# Altium schematic sheet Schematic_Sheet2.SchDoc(Schematic_Sheet2)
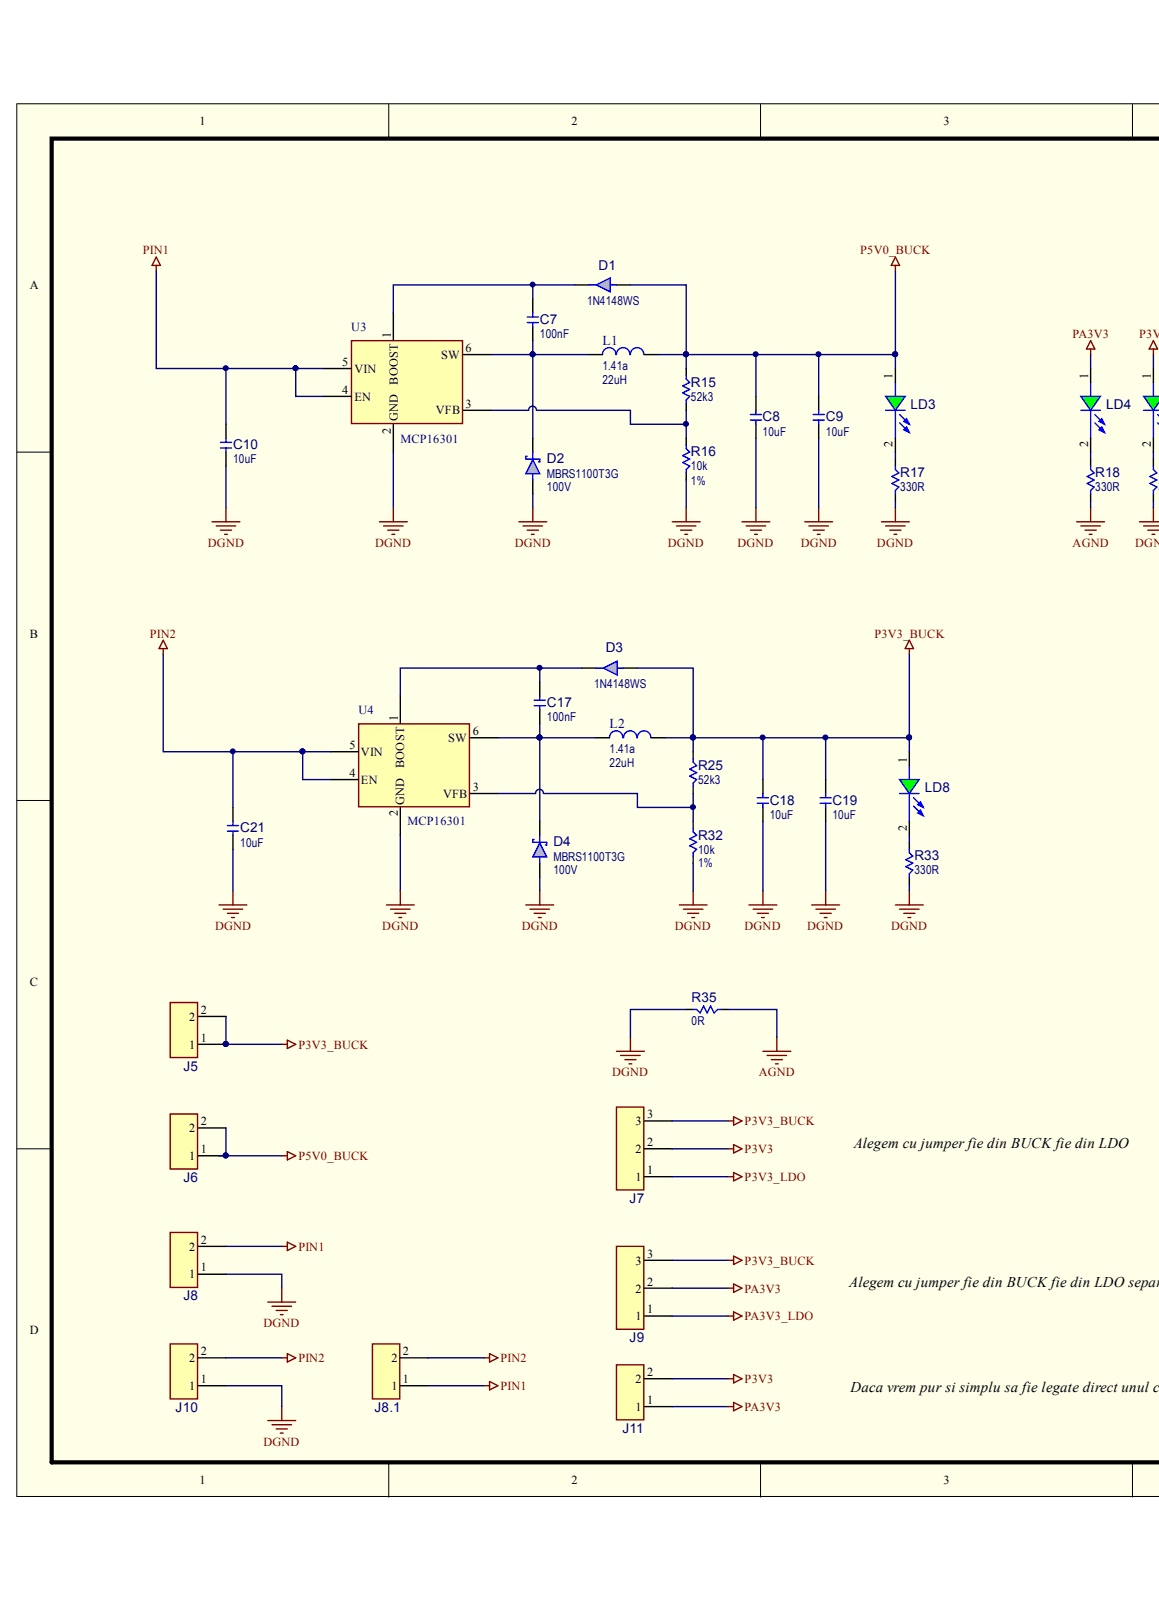
[diagram p0: Schematic_Sheet2.SchDoc, left]
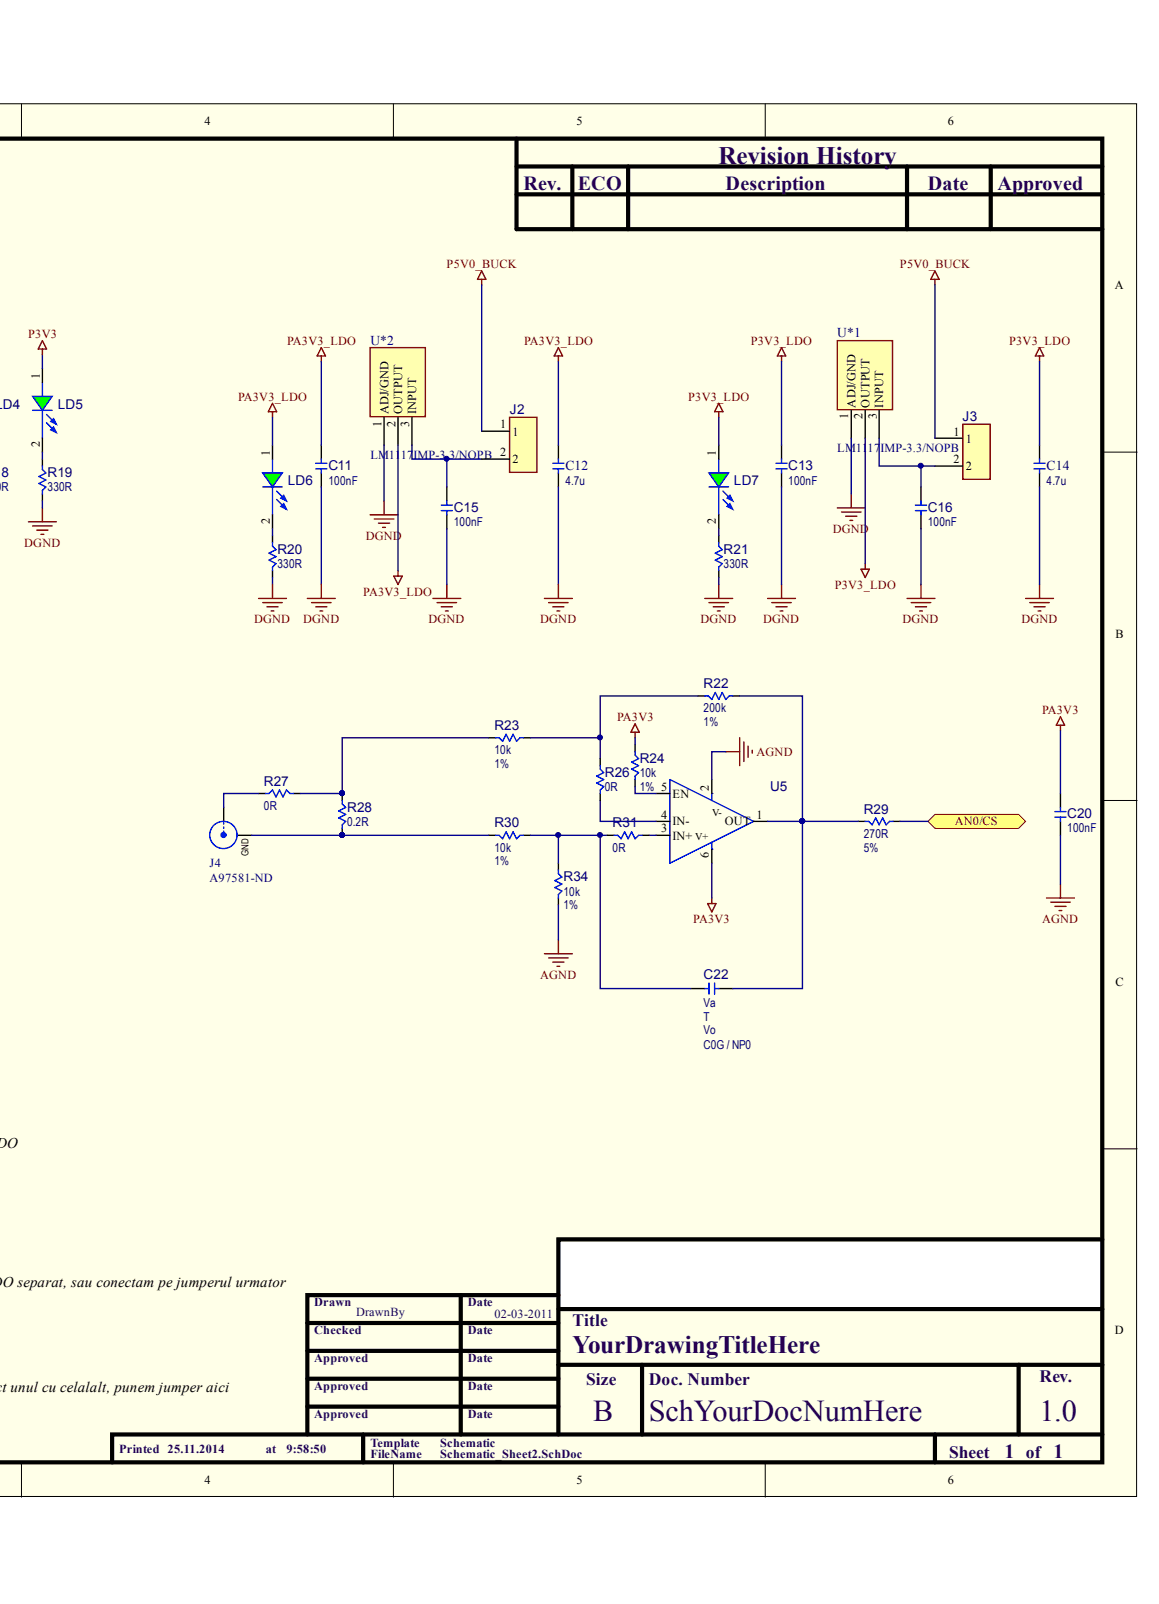
[diagram p1: Schematic_Sheet2.SchDoc, right]

## Parts
C7  value 100nF  (hidden comment: 06035C104JAT2A)
C8  value 10uF  (hidden comment: C3225X7S1H106M250AB)
C9  value 10uF  (hidden comment: C3225X7S1H106M250AB)
C10  value 10uF  (hidden comment: C3225X7S1H106M250AB)
C11  value 100nF  (hidden comment: 06035C104JAT2A)
C12  value 4.7u  (hidden comment: GRM21BR61C475KA88L)
C13  value 100nF  (hidden comment: 06035C104JAT2A)
C14  value 4.7u  (hidden comment: GRM21BR61C475KA88L)
C15  value 100nF  (hidden comment: 06035C104JAT2A)
C16  value 100nF  (hidden comment: 06035C104JAT2A)
C17  value 100nF  (hidden comment: 06035C104JAT2A)
C18  value 10uF  (hidden comment: C3225X7S1H106M250AB)
C19  value 10uF  (hidden comment: C3225X7S1H106M250AB)
C20  value 100nF  (hidden comment: 0603YC104JAT2A)
C21  value 10uF  (hidden comment: C3225X7S1H106M250AB)
C22  value Va  Tolerance: T  Voltage: Vo  (hidden comment: CAP_NP_0603)
D1  1N4148WS
D2  MBRS1100T3G  Vrrm: 100V
D3  1N4148WS
D4  MBRS1100T3G  Vrrm: 100V
J2  CON2  (value not drawn)
J3  CON2  (value not drawn)
J4  value A97581-ND  (hidden comment: BNC_Vert_5-1634503-1)
J5  CON2  (value not drawn)
J6  CON2  (value not drawn)
J7  CON3  (value not drawn)
J8  CON2  (value not drawn)
J8.1  CON2  (value not drawn)
J9  CON3  (value not drawn)
J10  CON2  (value not drawn)
J11  CON2  (value not drawn)
L1  value 22uH  Current: 1.41a  (hidden comment: IND_SMD_22uH_1.41A)
L2  value 22uH  Current: 1.41a  (hidden comment: IND_SMD_22uH_1.41A)
LD3  LED_GREEN_0603  (value not drawn)
LD4  LED_GREEN_0603  (value not drawn)
LD5  LED_GREEN_0603  (value not drawn)
LD6  LED_GREEN_0603  (value not drawn)
LD7  LED_GREEN_0603  (value not drawn)
LD8  LED_GREEN_0603  (value not drawn)
R15  value 52k3  (hidden comment: ERJ3EKF5232V)
R16  value 10k  Tolerance: 1%  (hidden comment: RES_0603_10k_1p)
R17  value 330R  (hidden comment: MCMR06X3300FTL)
R18  value 330R  (hidden comment: MCMR06X3300FTL)
R19  value 330R  (hidden comment: MCMR06X3300FTL)
R20  value 330R  (hidden comment: MCMR06X3300FTL)
R21  value 330R  (hidden comment: MCMR06X3300FTL)
R22  value 200k  Tolerance: 1%  (hidden comment: MCMR06X2003FTL)
R23  value 10k  Tolerance: 1%  (hidden comment: MCMR06X1002FTL)
R24  value 10k  Tolerance: 1%  (hidden comment: MCMR06X1002FTL)
R25  value 52k3  (hidden comment: ERJ3EKF5232V)
R26  value 0R  (hidden comment: ERJ3GEY0R00V)
R27  value 0R  (hidden comment: ERJ3GEY0R00V)
R28  value 0.2R  [Chip Resistor, 2-Leads, Body 3.2x1.6mm, IPC Medium Density]  (hidden comment: RES_0603)
R29  value 270R  Tolerance: 5%  (hidden comment: ERJ3GEYJ271V)
R30  value 10k  Tolerance: 1%  (hidden comment: MCMR06X1002FTL)
R31  value 0R  (hidden comment: ERJ3GEY0R00V)
R32  value 10k  Tolerance: 1%  (hidden comment: RES_0603_10k_1p)
R33  value 330R  (hidden comment: MCMR06X3300FTL)
R34  value 10k  Tolerance: 1%  (hidden comment: MCMR06X1002FTL)
R35  value 0R  (hidden comment: ERJ3GEY0R00V)
U3  MCP16301
U4  MCP16301
U5  LMP7711MK  (value not drawn)
U*1  LM1117IMP-3.3/NOPB
U*2  LM1117IMP-3.3/NOPB

## Ports
AN0/CS

## Nets
AGND: C20.1 R18.1 R34.1 R35.2 U5.2(V-)
DGND: C8.1 C9.1 C10.1 C11.1 C12.1 C13.1 C14.1 C15.1 C16.1 C18.1 C19.1 C21.1 D2.1 D4.1 J8.1 J10.1 R16.1 R17.1 R19.1 R20.1 R21.1 R32.1 R33.1 R35.1 U3.2(GND) U4.2(GND) U*1.1 U*2.1
P3V3: J7.2 J11.2 LD5.1
P3V3_BUCK: C18.2 C19.2 D3.1 J5.1 J5.2 J7.3 J9.3 L2.2 LD8.1 R25.2
P3V3_LDO: C13.2 C14.2 J7.1 LD7.1 U*1.2
P5V0_BUCK: C8.2 C9.2 D1.1 J2.1 J3.1 J6.1 J6.2 L1.2 LD3.1 R15.2
PA3V3: C20.2 J9.2 J11.1 LD4.1 R24.2 U5.6
PA3V3_LDO: C11.2 C12.2 J9.1 LD6.1 U*2.2
PIN1: C10.2 J8.2 J8.1.1 U3.4(EN) U3.5(VIN)
PIN2: C21.2 J8.1.2 J10.2 U4.4(EN) U4.5(VIN)
AN0/CS: R29.2 ; port AN0/CS
NetC7_1: C7.1 D2.2 L1.1 U3.6(SW)
NetC7_2: C7.2 D1.2 U3.1(BOOST)
NetC15_2: C15.2 J2.2 U*2.3
NetC16_2: C16.2 J3.2 U*1.3
NetC17_1: C17.1 D4.2 L2.1 U4.6(SW)
NetC17_2: C17.2 D3.2 U4.1(BOOST)
NetC22_1: C22.1 R30.2 R31.1 R34.2
NetC22_2: C22.2 R22.2 R29.1 U5.1(OUT)
NetJ4_1: J4.1 R27.1
NetJ4_2: J4.2 R28.2 R30.1
NetLD3_2: LD3.2 R17.2
NetLD4_2: LD4.2 R18.2
NetLD5_2: LD5.2 R19.2
NetLD6_2: LD6.2 R20.2
NetLD7_2: LD7.2 R21.2
NetLD8_2: LD8.2 R33.2
NetR15_1: R15.1 R16.2 U3.3(VFB)
NetR22_1: R22.1 R23.2 R26.2
NetR23_1: R23.1 R27.2 R28.1
NetR24_1: R24.1 U5.5(EN)
NetR25_1: R25.1 R32.2 U4.3(VFB)
NetR26_1: R26.1 U5.4(IN-)
NetR31_2: R31.2 U5.3(IN+)

## Library data of the parts
C7 C11 C13 C15-C17 = (no symbol name): Manufacturer: AVX; Type: SMD_0402; Type_Cap: Ceramic; Tolerance: 5%; Voltage: 50V; Dielectric: X7R; Supplier: Farnell; Supplier Part Number: 1740621; Manufacturer Part Number: 06035C104JAT2A
C8-C10 C18 C19 C21 = (no symbol name): Manufacturer: TDK; Type: SMD_1206; Type_Cap: Ceramic; Tolerance: 20%; Voltage: 50V; Dielectric: X7S; Supplier: Farnell; Supplier Part Number: 2309045; Manufacturer Part Number: C3225X7S1H106M250AB
C12 C14 = (no symbol name): Manufacturer: MURATA; Dielectric: X5R; Tolerance: 10%; Type: SMD_0805; Voltage: 16V; Type_CAP: Ceramic; Manufacturer Part Number: GRM21BR61C475KA88L; Supplier: Farnell; Supplier Part Number: 1907509
C20 = (no symbol name): Manufacturer: AVX; Type: SMD_0603; Type_Cap: Ceramic; Tolerance: 5%; Voltage: 16V; Dielectric: X7R; Supplier: Farnell; Supplier Part Number: 1740612; Manufacturer Part Number: 0603YC104JAT2A
C22 = (no symbol name): Type: SMD_0603; Type_Cap: Ceramic; Dielectric: C0G / NP0; Manufacturer: *; Supplier: Farnell; Supplier Part Number: *; Manufacturer Part Number: *; ESR: *
D1 D3 = (no symbol name): Manufacturer: MULTICOMP; If: 150mA; Vrmm: 100V; Manufacturer Part Number: 1N4148WS; Supplier: Farnell; Supplier Part Number: 1466524; Value: 1N4148WS
D2 D4 = (no symbol name): Manufacturer: ON SEMICONDUCTOR; If: 1A; Manufacturer Part Number: MBRS1100T3G; Supplier: Farnell; Supplier Part Number: 9555862; Value: MBRS1100T3G
J4 = (no symbol name): Manufacturer: TE Connectivity; Supplier: Farnell; Manufacturer Part Number: 5-1634503-1; Supplier Part Number: 1020980
L1 L2 = (no symbol name): Manufacturer: WURTH; Manufacturer Part Number: 7447779122; Tolerance: 20%; Supplier: Farnell; Supplier Part Number: 1635991
LD3-LD8 = (no symbol name): Manufacturer: OSRAM; Manufacturer Part Number: LGQ971; Type: SMD_0603; Vf: 2.2V; If: 20mA; Color: Green; Supplier: Farnell; Supplier Part Number: 1226372
R15 R25 = (no symbol name): Manufacturer: PANASONIC; Power: 100mW; Tolerance: 1%; Manufacturer Part Number: ERJ3EKF5232V; Type: SMD_0603; Supplier: Farnell; Supplier Part Number: 2059474
R16 R32 = (no symbol name): Manufacturer: MULTICOMP; Manufacturer Part Number: MCMR06X1002FTL; Power: 100mW; Type: SMD_0603; Supplier: Farnell; Supplier Part Number: 2073349
R17-R21 R33 = (no symbol name): Manufacturer: MULTICOMP; Power: 125mW; Tolerance: 1%; Manufacturer Part Number: MCMR06X3300FTL; Type: SMD_0603; Supplier: Farnell; Supplier Part Number: 2073472
R22-R24 R30 R34 = (no symbol name): Manufacturer: MULTICOMP; Power: 100mW; Type: SMD_0603; Supplier: Farnell
  R22: Manufacturer Part Number: MCMR06X2003FTL; Supplier Part Number: 2073422
  R23 R24 R30 R34: Manufacturer Part Number: MCMR06X1002FTL; Supplier Part Number: 2073349
R26 R27 R29 R31 R35 = (no symbol name): Manufacturer: PANASONIC; Power: 100mW; Type: SMD_0603; Supplier: Farnell
  R26 R27 R31 R35: Manufacturer Part Number: ERJ3GEY0R00V; Supplier Part Number: 2059527; Tolerance: -
  R29: Manufacturer Part Number: ERJ3GEYJ271V; Supplier Part Number: 2059585
U3 U4 = (no symbol name): Manufacturer: Microchip; Manufacturer Part Number: MCP16301T-E/CH; Supplier Part Number: MCP16301T-E/CH; Supplier: Farnell; Type: Switcher BUCK
U5 = (no symbol name): Manufacturer: TI; Value: LMP7711MK; Supplier: Farnell; Type: SMD; Supplier Part Number: 1312608; Manufacturer Part Number: LMP7711MK
U*1 U*2 = (no symbol name): Manufacturer: TI; Input Voltage: 2.6V to 16V; Output Voltage: 3.3V; Manufacturer Part Number: LM1117IMP-3.3/NOPB; Supplier: Farnell; Supplier Part Number: 1469051; Temperature Range: -40°C +125°C
J2 J3 J5-J8 J8.1 J9-J11 R28 = (no symbol name): Manufacturer: *; Supplier: *; Manufacturer Part Number: *; Supplier Part Number: *
  J2 J3 J5-J8 J8.1 J9-J11: Type: THT; Value: *
  R28: Type: SMD_1210; Power: *
